# Altium schematic sheet SENSORS_XS.SchDoc
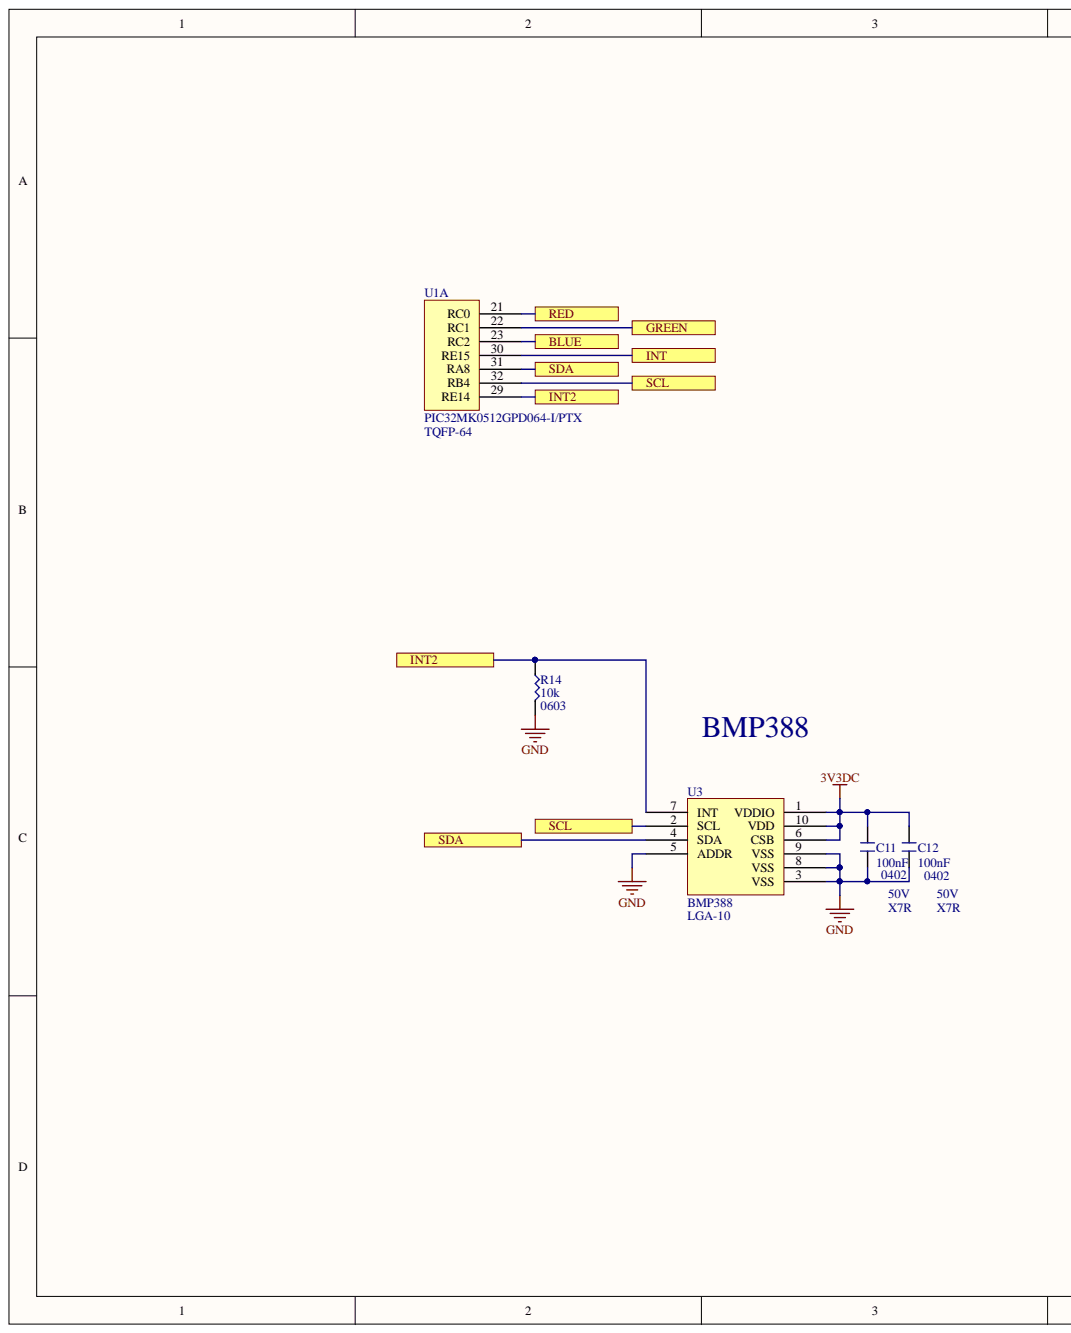
[diagram p0: SENSORS_XS.SchDoc, left]
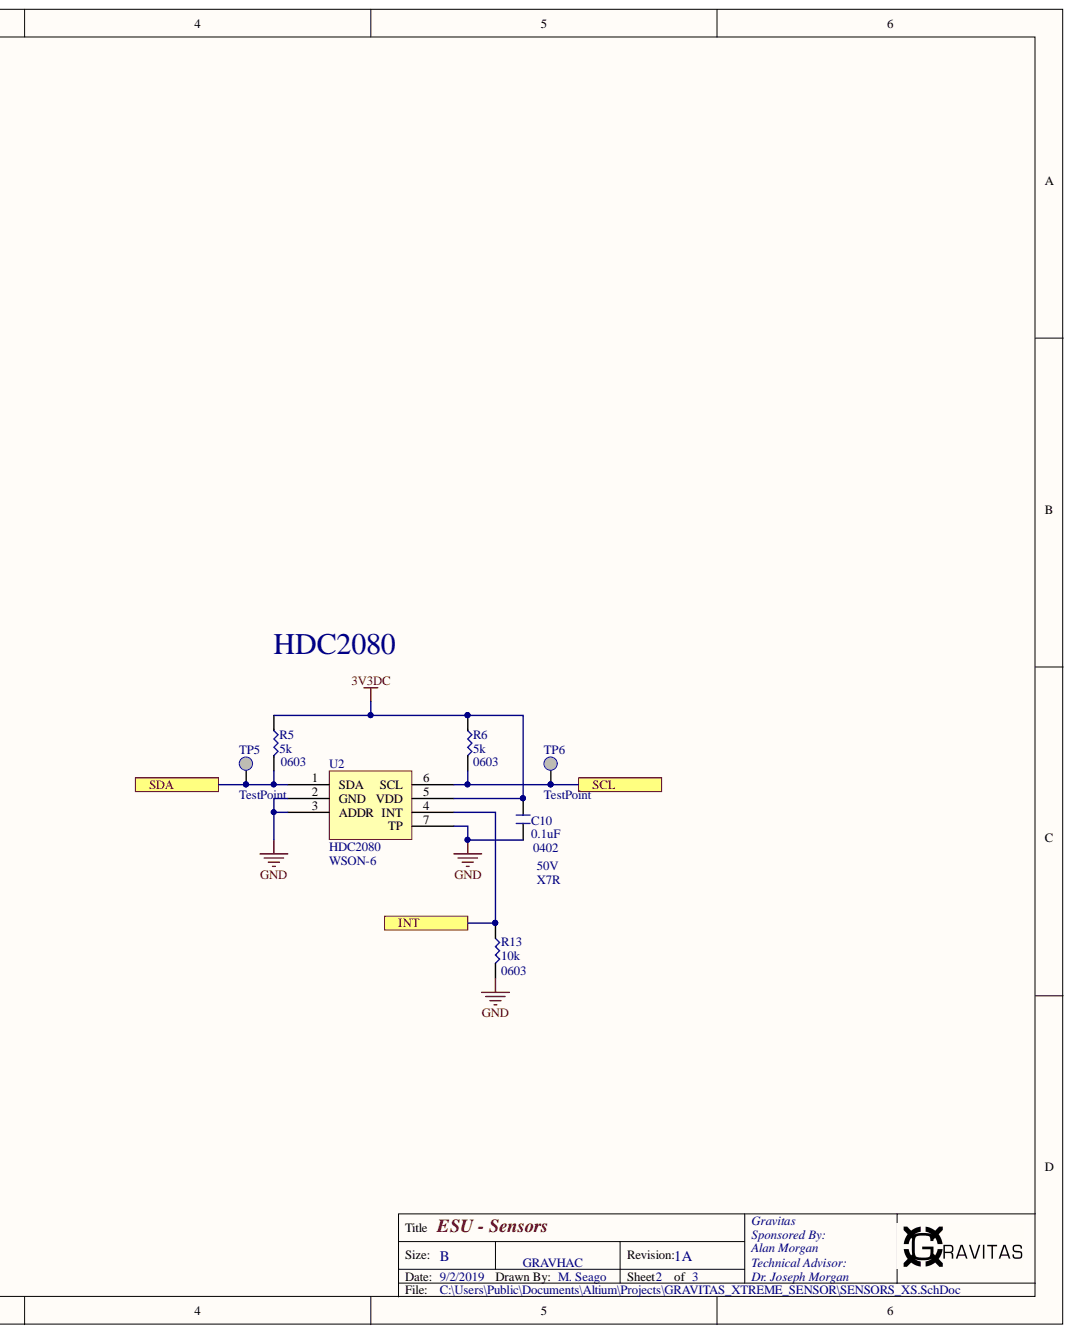
[diagram p1: SENSORS_XS.SchDoc, right]

## Parts
C10  0.1uF  [NonPolar-0402]
C11  100nF  [NonPolar-0402]
C12  100nF  [NonPolar-0402]
R5  5k  [NonPolar-0603]
R6  5k  [NonPolar-0603]
R13  10k  [NonPolar-0603]
R14  10k  [NonPolar-0603]
TP5  TestPoint  [TP - S2751-46R]
TP6  TestPoint  [TP - S2751-46R]
U1  PIC32MK0512GPD064-I/PTX  [PIC32MK0512GPD064]  (value not drawn)  (part A)
U2  HDC2080  [HDC2080]
U3  BMP388  [BMP388]

## Ports
BLUE, GREEN, INT, INT2, RED, SCL, SDA

## Nets
3V3DC: C10.2 C11.2 C12.2 R5.2 R6.2 U2.5(VDD) U3.1(VDDIO) U3.6(CSB) U3.10(VDD)
GND: C10.1 C11.1 C12.1 R13.1 R14.1 U2.2(GND) U2.3(ADDR) U2.7(TP) U3.3(VSS) U3.5(ADDR) U3.8(VSS) U3.9(VSS)
BLUE: U1.23(RC2) ; port BLUE
GREEN: U1.22(RC1) ; port GREEN
INT: R13.2 U1.30(RE15) U2.4(INT) ; port INT
INT2: R14.2 U1.29(RE14) U3.7(INT) ; port INT2
RED: U1.21(RC0) ; port RED
SCL: R6.1 TP6.1 U1.32(RB4) U2.6(SCL) U3.2(SCL) ; port SCL
SDA: R5.1 TP5.1 U1.31(RA8) U2.1(SDA) U3.4(SDA) ; port SDA

## Library data of the parts
C10-C12 = Cap - 0402: Component Kind: Standard; Library Name: GravLib.IntLib
R5 R6 R13 R14 = Res - 0603: Component Kind: Standard; Library Name: GravLib.IntLib
TP5 TP6 = TestPoint: S2751-46R; Component Kind: Standard; Library Name: GravLib.IntLib
U1 = PIC32MK0512GPD064-I/PTX: Component Kind: Standard; Library Name: GravLib.IntLib
U2 = HDC2080: Component Kind: Standard; Library Name: GravLib.IntLib
U3 = BMP388: Component Kind: Standard; Library Name: GravLib.IntLib
